# Altium schematic sheet 02_LAN7800_Controller.SchDoc(02_LAN7800_Controller)
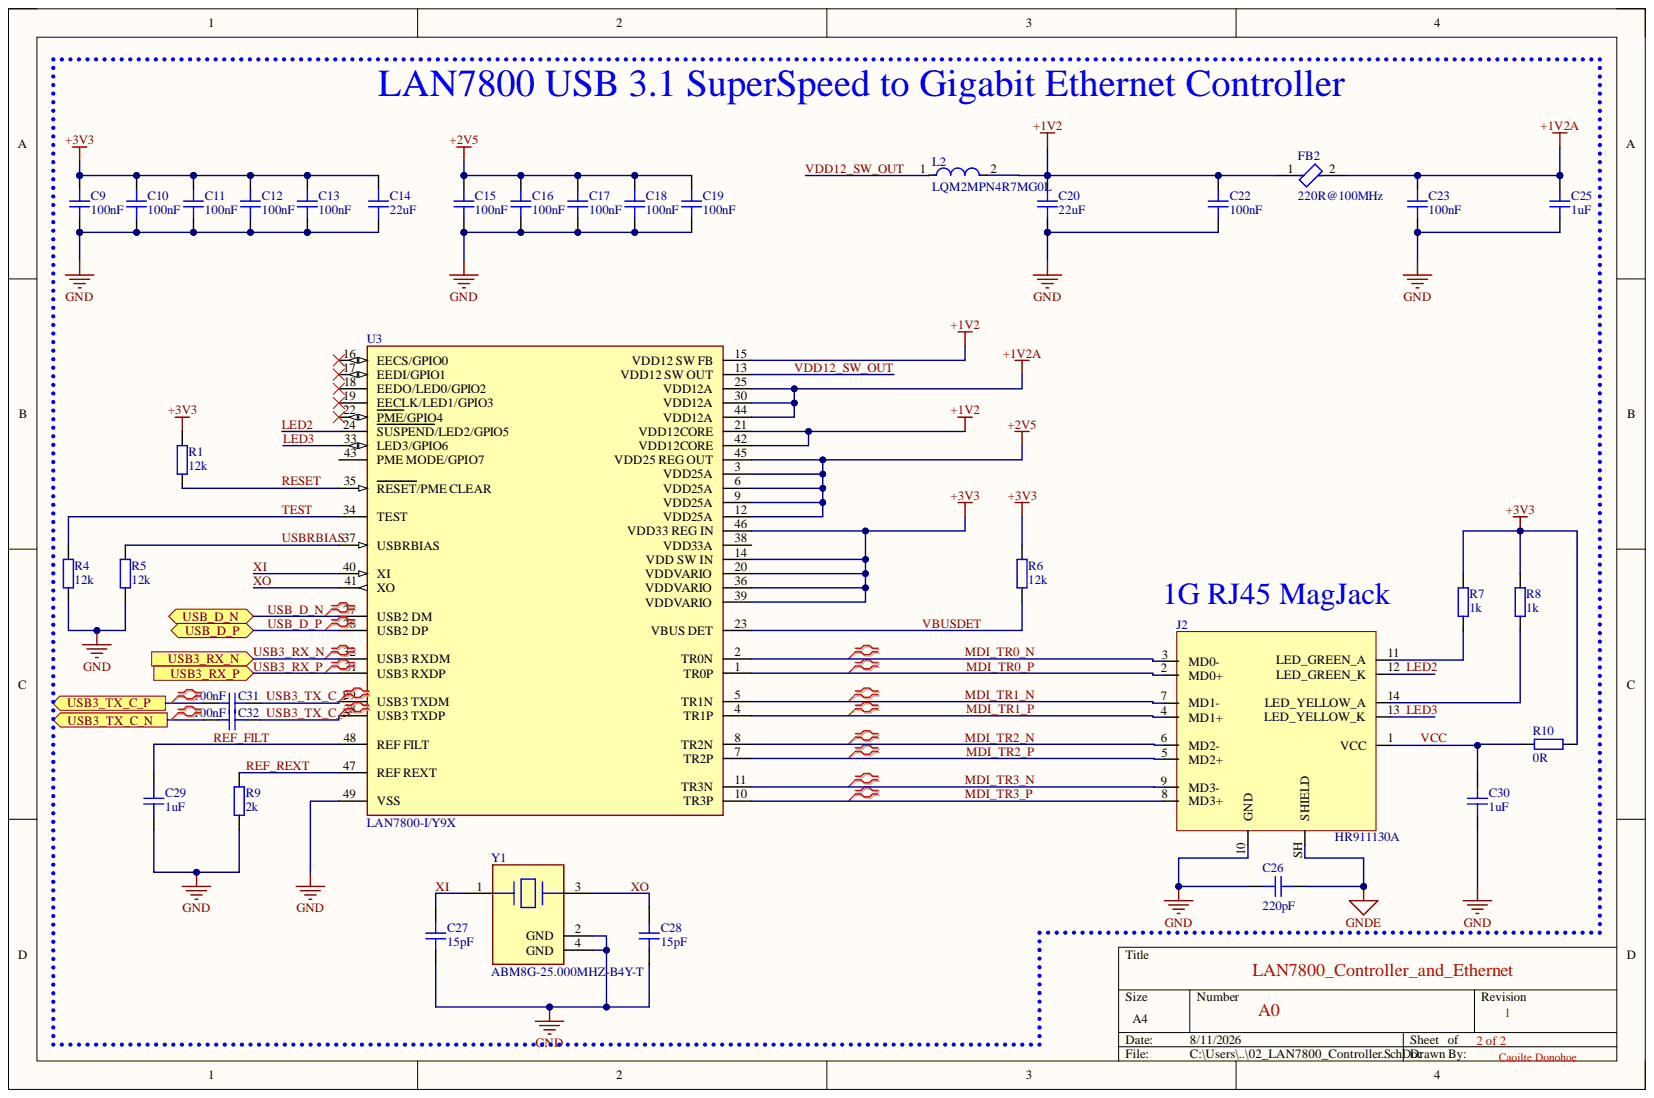
[diagram p0: 02_LAN7800_Controller.SchDoc]

## Parts
C9  100nF  [CAP_0402]
C10  100nF  [CAP_0402]
C11  100nF  [CAP_0402]
C12  100nF  [CAP_0402]
C13  100nF  [CAP_0402]
C14  22uF  [CAP_0603]
C15  100nF  [CAP_0402]
C16  100nF  [CAP_0402]
C17  100nF  [CAP_0402]
C18  100nF  [CAP_0402]
C19  100nF  [CAP_0402]
C20  22uF  [CAP_0603]
C22  100nF  [CAP_0402]
C23  100nF  [CAP_0402]
C25  1uF  [CAP_0402]
C26  220pF  [CAP_0603]
C27  15pF  [CAP_0603]
C28  15pF  [CAP_0603]
C29  1uF  [CAP_0402]
C30  1uF  [CAP_0402]
C31  100nF  [CAP_0402]
C32  100nF  [CAP_0402]
FB2  220R@100MHz  [FP-BLM21-0_2-t0_85-e0_5-IPC_C]
J2  HR911130A  [HR911130A]
L2  LQM2MPN4R7MG0L  [FP-LQM2MPN_G0-IPC_B]
R1  12k  [RES_0402]
R4  12k  [RES_0402]
R5  12k  [RES_0402]
R6  12k  [RES_0402]
R7  1k  [RES_0402]
R8  1k  [RES_0402]
R9  2k  [RES_0402]
R10  0R  [RES_0402]
U3  LAN7800-I/Y9X  [FP-48SQFN-5304-7x7B-IPC_B]
Y1  ABM8G-25.000MHZ-B4Y-T  [FP-ABM8G-MFG]

## Ports
USB3_RX_N, USB3_RX_P, USB3_TX_C_N, USB3_TX_C_P, USB_D_N, USB_D_P

## Nets
+1V2: C20.2 C22.2 FB2.1 L2.2 U3.15(VDD12_SW_FB) U3.21(VDD12CORE) U3.42(VDD12CORE)
+1V2A: C23.2 C25.2 FB2.2 U3.25(VDD12A) U3.30(VDD12A) U3.44(VDD12A)
+2V5: C15.2 C16.2 C17.2 C18.2 C19.2 U3.3(VDD25A) U3.6(VDD25A) U3.9(VDD25A) U3.12(VDD25A) U3.45(VDD25_REG_OUT)
+3V3: C9.2 C10.2 C11.2 C12.2 C13.2 C14.2 R1.2 R6.1 R7.1 R8.1 R10.2 U3.14(VDD_SW_IN) U3.20(VDDVARIO) U3.36(VDDVARIO) U3.39(VDDVARIO) U3.46(VDD33_REG_IN)
GND: C9.1 C10.1 C11.1 C12.1 C13.1 C14.1 C15.1 C16.1 C17.1 C18.1 C19.1 C20.1 C22.1 C23.1 C25.1 C26.1 C27.1 C28.1 C29.1 C30.1 J2.10(GND) R4.1 R5.1 R9.1 U3.49(VSS) Y1.2(GND) Y1.4(GND)
GNDE: C26.2 J2.SH(SHIELD)
LED2: J2.12(LED_GREEN_K) U3.24(SUSPEND/LED2/GPIO5)
LED3: J2.13(LED_YELLOW_K) U3.33(LED3/GPIO6)
MDI_TR0_N: J2.3(MD0-) U3.2(TR0N)
MDI_TR0_P: J2.2(MD0+) U3.1(TR0P)
MDI_TR1_N: J2.7(MD1-) U3.5(TR1N)
MDI_TR1_P: J2.4(MD1+) U3.4(TR1P)
MDI_TR2_N: J2.6(MD2-) U3.8(TR2N)
MDI_TR2_P: J2.5(MD2+) U3.7(TR2P)
MDI_TR3_N: J2.9(MD3-) U3.11(TR3N)
MDI_TR3_P: J2.8(MD3+) U3.10(TR3P)
REF_FILT: C29.2 U3.48(REF_FILT)
REF_REXT: R9.2 U3.47(REF_REXT)
RESET: R1.1 U3.35(RESET/PME_CLEAR)
TEST: R4.2 U3.34(TEST)
USB3_RX_N: U3.32(USB3_RXDM) ; port USB3_RX_N
USB3_RX_P: U3.31(USB3_RXDP) ; port USB3_RX_P
USB3_TX_C_N: C32.1 U3.28(USB3_TXDP)
USB3_TX_C_P: C31.1 U3.29(USB3_TXDM)
USB_D_N: U3.27(USB2_DM) ; port USB_D_N
USB_D_P: U3.26(USB2_DP) ; port USB_D_P
USBRBIAS: R5.2 U3.37(USBRBIAS)
VBUSDET: R6.2 U3.23(VBUS_DET)
VCC: C30.2 J2.1(VCC) R10.1
VDD12_SW_OUT: L2.1 U3.13(VDD12_SW_OUT)
XI: C27.2 U3.40(XI) Y1.1
XO: C28.2 U3.41(XO) Y1.3
NetC31_2: C31.2 ; port USB3_TX_C_P
NetC32_2: C32.2 ; port USB3_TX_C_N
NetJ2_11: J2.11(LED_GREEN_A) R7.2
NetJ2_14: J2.14(LED_YELLOW_A) R8.2

Not connected: U3 16(EECS/GPIO0) 17(EEDI/GPIO1) 18(EEDO/LED0/GPIO2) 19(EECLK/LED1/GPIO3) 22(PME/GPIO4) 38(VDD33A) 43(PME_MODE/GPIO7)

## Library data of the parts
C9-C13 C15-C19 C22 C23 C25 C29-C32 = CAP_0402: Capacitor SMD 0402; Component Kind: Standard; Library Name: Schematic-Lib-PMS_Caoilte.SchLib
C14 C20 C26-C28 = CAP_0603: Capacitor SMD 0603; Component Kind: Standard; Library Name: Schematic-Lib-PMS_Caoilte.SchLib
FB2 = CMP-0686-00610-3: Chip Ferrite Bead, 0805, 220Ω @ 100MHz, 0.045Ω, 25%, 2A; DC Resistance (DCR): 45mR; Impedance: 220R; Number of Circuits: 1; Shielding: Unshielded; Case Code (Imperial): 0805; Case Code (Metric): 2012; Case/Package: 0805; Component Kind: Standard; Contact Plating: Tin; Core Material: Ferrite; Current: 2A; Current Rating: 2A; DC Current: 2A; DC Resistance-Max: 45mR; Depth: 1.25mm; Frequency: 100MHz; Height: 0.85mm; Lead Free: Lead Free; Length: 2mm; Library Name: LAN7800_USB_Ethernet_RevA.SchLib; Material: Ferrite; Max Current Rating: 2A; Max DC Current: 2A; Max Operating Temperature: 125°C; Military Standard: Not; Min Operating Temperature: -55°C; Number of Lines: 1; Number of Pins: 2; Package Quantity: 1; Packaging: Tape and Reel; Radiation Hardening: No; REACH SVHC: No SVHC; RoHS Compliant: Yes; Series: BLM21P; Termination: SMD/SMT; Test Frequency: 100MHz; Tolerance: 25%; Width: 1.25mm
J2 = HR911130A: 100/1000Base-T Magnetics Single-Port RJ45 Connector with Integrated Magnetics and LEDs; Manufacturer: Hanrun; Component Kind: Standard; Library Name: LAN7800_USB_Ethernet_RevA.SchLib; Manufacturer Part Number: HR911130A
L2 = CMP-06042-016483-1: Multilayer Type Inductor for Power Lines, 0806 (2016), 4.7μH, 20%, 0.175Ω, 1.1A; Core Material: Ferrite; DC Resistance (DCR): 175mR; Inductance: 4.7uH; Self Resonant Frequency: 20MHz; Shielding: Shielded; Test Frequency: 1MHz; Case Code (Imperial): 0806; Case Code (Metric): 2016; Case/Package: 0805; Component Kind: Standard; Current Rating: 1.1A; DC Current: 1.1A; Depth: 1.75mm; Height: 0.9mm; Height - Seated (Max): 0.039inch; Length: 0.079inch; Library Name: LAN7800_USB_Ethernet_RevA.SchLib; Max DC Current: 1.1A; Max Operating Temperature: 125°C; Military Standard: Not; Min Operating Temperature: -55°C; Mount: Surface Mount; Package Quantity: 3000; Packaging: Tape and Reel; RoHS Compliant: Yes; Termination: SMD/SMT; Tolerance: 20%; Weight: 0.000353oz; Width: 0.049inch
R1 R4-R10 = RES_0402: Resistor SMD 0402; Component Kind: Standard; Library Name: Schematic-Lib-PMS_Caoilte.SchLib
U3 = CMP-06298-000014-1: IC ETHERNET CTLR USB 48SQFN; Operating Supply Current: 4mA; Component Kind: Standard; Library Name: LAN7800_USB_Ethernet_RevA.SchLib; Max Operating Temperature: 85°C; Min Operating Temperature: -40°C; Packaging: Tray
Y1 = CMP-27762-000021-2: Crystal 25MHz ±30ppm (Tol) ±30ppm (Stability) 10pF FUND 60Ω 4-Pin SMD T/R; ESR (Equivalent Series Resistance): 60R; Case/Package: CSMD; Component Kind: Standard; Depth: 2.5mm; Frequency: 25MHz; Frequency Stability: 30ppm; Frequency Tolerance: 30ppm; Height: 0.039inch; Lead Free: Lead Free; Length: 3.2mm; Library Name: LAN7800_USB_Ethernet_RevA.SchLib; Load Capacitance: 10pF; Max Operating Temperature: 70°C; Min Operating Temperature: -20°C; Mount: Surface Mount; Number of Pins: 4; Operating Frequency: 25 MHz; Package Quantity: 1000; Packaging: Tape and Reel; Series: ABM8G; Series Resistance: 60R; Termination: SMD/SMT; Tolerance: 30ppm; Width: 0.098inch
